# Altium schematic sheet Header.SchDoc(Header)
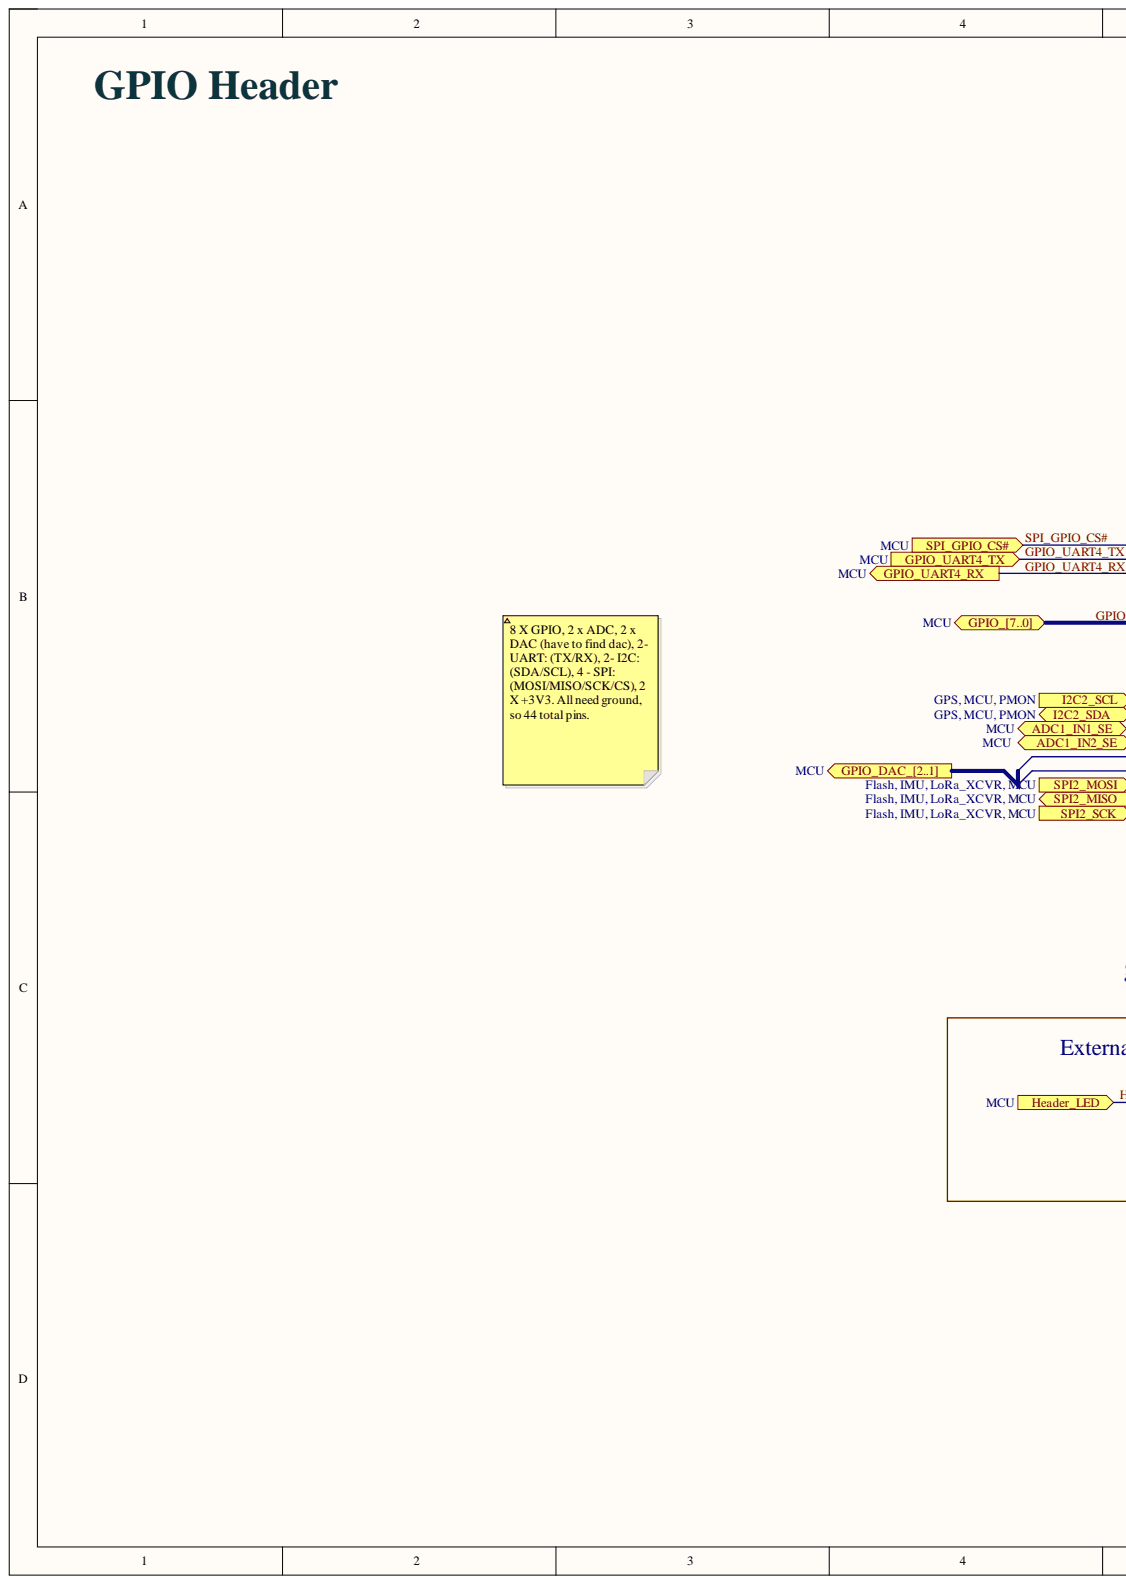
[diagram p0: Header.SchDoc, left]
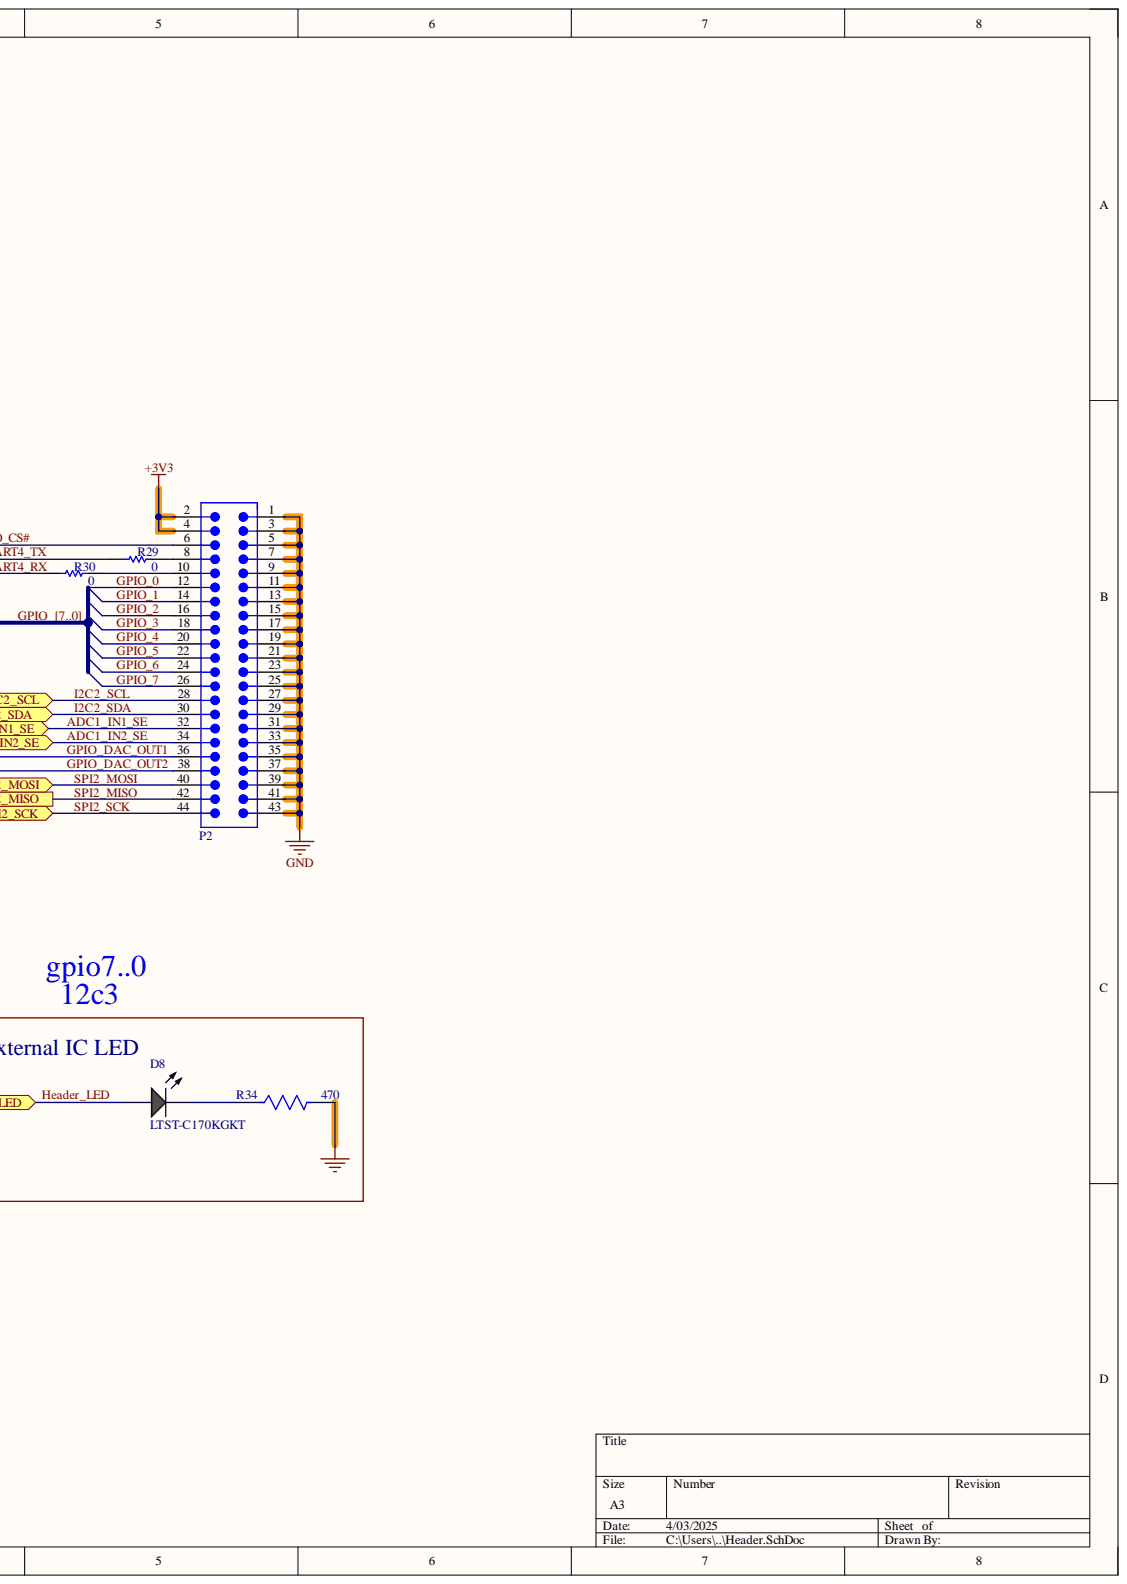
[diagram p1: Header.SchDoc, right]

## Parts
D8  LTST-C170KGKT  [LTST-LTST-C170KGKT-2_V]
P2  62004421121  [62004421121]  (value not drawn)
R29  0  [RESC1005X40X25LL05T05]
R30  0  [RESC1005X40X25LL05T05]
R34  470  [FP-CPF0603-MFG]

## Ports
ADC1_IN1_SE, ADC1_IN2_SE, GPIO_0, GPIO_1, GPIO_2, GPIO_3, GPIO_4, GPIO_5, GPIO_6, GPIO_7, GPIO_[7..0], GPIO_DAC_1, GPIO_DAC_2, GPIO_DAC_[2..1], GPIO_UART4_RX, GPIO_UART4_TX, Header_LED, I2C2_SCL, I2C2_SDA, SPI2_MISO, SPI2_MOSI, SPI2_SCK, SPI_GPIO_CS#

## Nets
+3V3: P2.2 P2.4
GND: P2.1 P2.3 P2.5 P2.7 P2.9 P2.11 P2.13 P2.15 P2.17 P2.19 P2.21 P2.23 P2.25 P2.27 P2.29 P2.31 P2.33 P2.35 P2.37 P2.39 P2.41 P2.43 R34.2
ADC1_IN1_SE: P2.32 ; port ADC1_IN1_SE
ADC1_IN2_SE: P2.34 ; port ADC1_IN2_SE
GPIO_0: P2.12 ; port GPIO_[7..0]  [also labelled GPIO_]
GPIO_1: P2.14 ; port GPIO_[7..0]  [also labelled GPIO_]
GPIO_2: P2.16 ; port GPIO_[7..0]  [also labelled GPIO_]
GPIO_3: P2.18 ; port GPIO_[7..0]  [also labelled GPIO_]
GPIO_4: P2.20 ; port GPIO_[7..0]  [also labelled GPIO_]
GPIO_5: P2.22 ; port GPIO_[7..0]  [also labelled GPIO_]
GPIO_6: P2.24 ; port GPIO_[7..0]  [also labelled GPIO_]
GPIO_7: P2.26 ; port GPIO_[7..0]  [also labelled GPIO_]
GPIO_DAC_OUT1: P2.36
GPIO_DAC_OUT2: P2.38
GPIO_UART4_RX: R30.2 ; port GPIO_UART4_RX
GPIO_UART4_TX: R29.2 ; port GPIO_UART4_TX
Header_LED: D8.2 ; port Header_LED
I2C2_SCL: P2.28 ; port I2C2_SCL
I2C2_SDA: P2.30 ; port I2C2_SDA
SPI2_MISO: P2.42 ; port SPI2_MISO
SPI2_MOSI: P2.40 ; port SPI2_MOSI
SPI2_SCK: P2.44 ; port SPI2_SCK
SPI_GPIO_CS#: P2.6 ; port SPI_GPIO_CS#
NetD8_1: D8.1 R34.1
NetP2_8: P2.8 R29.1
NetP2_10: P2.10 R30.1
N00002_1: ; port GPIO_DAC_[2..1]
N00002_2: ; port GPIO_DAC_[2..1]

## Library data of the parts
D8 = CMP-2000-06620-1: Ultra Bright AlInGaP Chip LED, 75 mW, -55 to 85 degC, 2-Pin SMD (0805), RoHS, Tape and Reel; Manufacturer: Lite-On; Chip Material: AlGaInP; DELETED: Compliant; Dominant Wave Length: 571nm; Emitting Color: YellowGreen; Forward Current: 30mA; Forward Voltage: 2.4V; High Brightness: Yes; Lum Intensity: 35mcd; Number of  Elements: 1; Peak Wavelength: 574nm; Power Dissipation: 75W; Power Dissipation Max: 75mW; Product Depth (mm): 1.25mm; Product Height (mm): 1.1mm; Product Length (mm): 2mm; Rad Hardened: No; Reverse Voltage: 5V; Shape Type: Rectangular; Test Current (It): 20mA; Type: Uni-Color; Viewing Angle: 130deg; Component Kind: Standard; Datasheet URL: http://optoelectronics.liteon.com/upload/download/DS22-2000-073/S_110_LTST-C170KGKT.pdf; Datasheet Version: Rev. G, 06/2005; Forward Current Max: 30mA; Lens Dimensions: 1.4x1.25x0.6mm; Library Name: Altium Content Vault; Luminous Intensity: 35mcd; Main Category: ChipLED; Manufacturer URL: http://www.us.liteon.com/; Mounting: SurfaceMount; Mounting Technology: SurfaceMount; Operating Temp Range: -55Cto85C; Operating Temperature Classification: Industrial; Package Description: 2-Pin Surface Mounted Device, Body 2 x 1.25 mm; Package Reference: LTST-C170KGKT-2; Package Type: ChipLed; Packaging: TapeandReel; Pin Count: 2
P2 = CMP-1502-00974-3: NexarPartId: 61924794; Contact Resistance: 20mΩ; Current Rating: 2A; Gender: Male; Operating Supply Voltage: 200V; Component Kind: Standard; Lead Pitch: 2mm; Library Name: Altium Content Vault; Number of Pins: 44; Orientation: Vertical; RoHS: Non-Compliant
R29 R30 = CMP-2000-07451-1: Chip Resistor, 0 Ohm, 0.1 W, -55 to 155 degC, 0402 (1005 Metric), RoHS, Tape and Reel; Manufacturer: Panasonic; Max Power Dissipation: 100mW; Resistance: 0mOhm; Temperature Coefficient: 600ppm/��C; Tolerance: 5%; Voltage Rating: 50V; Case Code (Imperial): 0402; Case Code (Metric): 1005; Case/Package: 0402; Component Kind: Standard; Composition: ThickFilm; Contact Plating: Tin; Datasheet URL: https://industrial.panasonic.com/cdbs/www-data/pdf/RDA0000/AOA0000C301.pdf; Datasheet Version: 03/2015; Depth: 0.5mm; Height: 0.35mm; Lead Free: LeadFree; Length: 1mm; Library Name: Altium Content Vault; Manufacturer URL: http://www.panasonic.com/; Max Operating Temperature: 155degC; Military Standard: Not; Min Operating Temperature: -55degC; Mount: SurfaceMount; Mounting Technology: SurfaceMount; Number of Terminations: 2; Package Description: 2-Pin Surface Mount Device, Body 1 x 0.5 mm; Package Quantity: 1; Package Reference: 402; Packaging: TapeandReel; Power Rating: 100mW; Radiation Hardening: No; REACH SVHC: NoSVHC; RoHSCompliant: True; Termination: SMD/SMT; Voltage Rating (DC): 50V; Width: 0.02inch
R34 = CMP-03211-004765-1: RES SMD 470Ω 0.1% 1/16W 0603    CPF0603B470RE; Manufacturer: TE Connectivity; Power Rating: 0.063W; Temperature Coefficient: 25ppm/°C; Voltage Rating: 50V; Automotive: No; Category: Res; Component Kind: Standard; ComponentLink2Description: Datasheet; ComponentLink2URL: https://www.te.com/commerce/DocumentDelivery/DDEController?Action=showdoc&DocId=Data+Sheet%7F1773200%7FM%7Fpdf%7FEnglish%7FENG_DS_1773200_M.pdf%7F3-1676481-2; Composition: Thin Film; Device Class L1: Passive Components; Device Class L2: Resistors; Device Class L3: Chip SMD Resistors; Height: 0.55mm; Ipc Land Pattern Name: RESC15580X45; Lead Free: Yes; Library Name: Altium Content Vault; Manufacturer Part Number: CPF0603B470RE; Octopart: https://octopart.com/cpf0603b470re-te+connectivity-23376607; octopart_url: https://octopart.com/cpf0603b470re-te+connectivity-23376607; Package: CPF0603; packaging: 5k Reel; Resistance: 470Ω; RoHS: TRUE; Series: CPF; Temperature: -55°C to +155°C; Temperature Range High: +155°C; Temperature Range Low: -55°C; Tolerance: 0.1%; Value: 470Ω
